# Altium schematic sheet BLE_Sensors_LEDs.SchDoc(BLE_Sensors_LEDs)
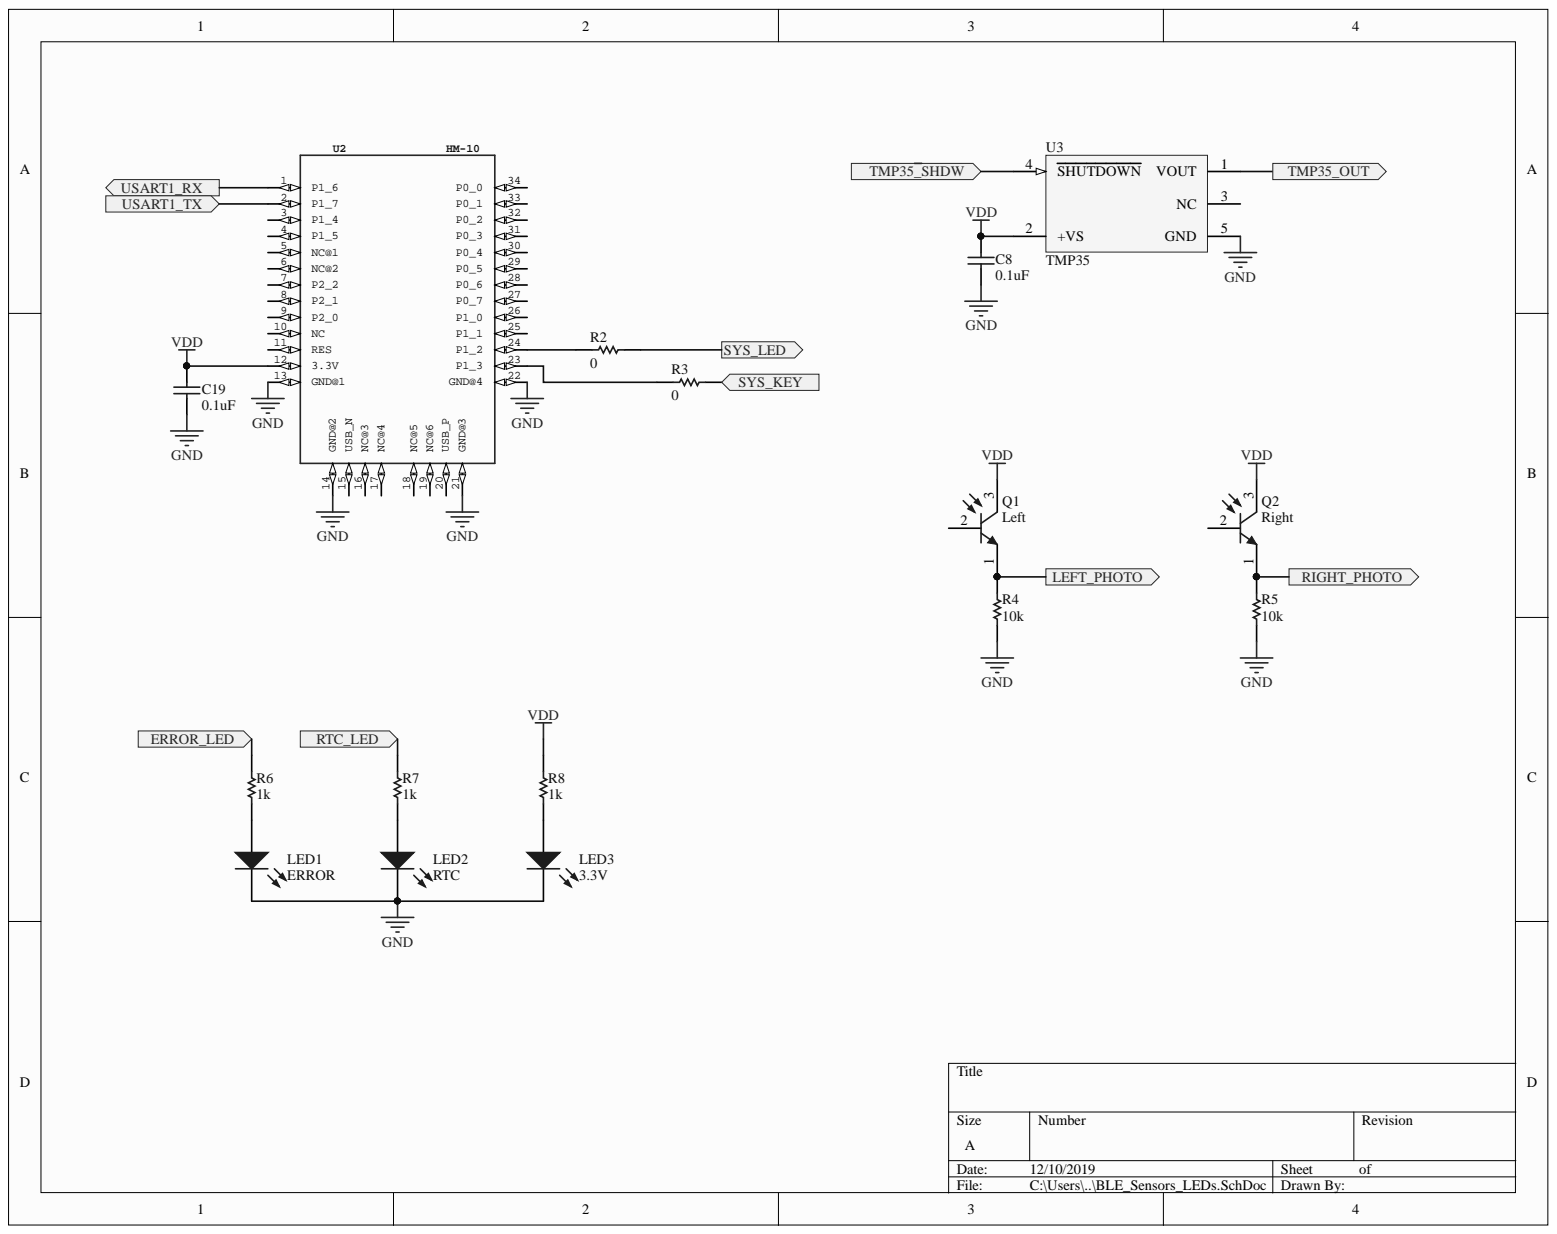
[diagram p0: BLE_Sensors_LEDs.SchDoc]

## Parts
C8  0.1uF  [CAPC1608X90X40ML10T20]
C19  0.1uF  [CAPC1608X90X40ML10T20]
LED1  ERROR  [0805_A]
LED2  RTC  [0805_A]
LED3  3.3V  [0805_A]
Q1  Left  [VSIL-TEMT6000X01_V]
Q2  Right  [VSIL-TEMT6000X01_V]
R2  0  [RESC2013X50X30LL20T20]
R3  0  [RESC2013X50X30LL20T20]
R4  10k  [RESC1608X55X30LL15T15]
R5  10k  [RESC1608X55X30LL15T15]
R6  1k  [RESC1608X55X30LL20T20]
R7  1k  [RESC1608X55X30LL20T20]
R8  1k  [RESC1608X55X30LL20T20]
U2  HM-10  [HM-10]
U3  TMP35  [ADI-RJ-5_M]

## Ports
ERROR_LED, LEFT_PHOTO, RIGHT_PHOTO, RTC_LED, SYS_KEY, SYS_LED, TMP35_OUT, TMP35~{_}SHDW, USART1_RX, USART1_TX

## Nets
GND: C8.1 C19.1 LED1.1 LED2.1 LED3.1 R4.1 R5.1 U2.13(GND@1) U2.14(GND@2) U2.21(GND@3) U2.22(GND@4) U3.5(GND)
VDD: C8.2 C19.2 Q1.3 Q2.3 R8.2 U2.12(3.3V) U3.2(+VS)
ERROR_LED: R6.2 ; port ERROR_LED
LEFT_PHOTO: Q1.1 R4.2 ; port LEFT_PHOTO
RIGHT_PHOTO: Q2.1 R5.2 ; port RIGHT_PHOTO
RTC_LED: R7.2 ; port RTC_LED
SYS_KEY: R3.2 ; port SYS_KEY
SYS_LED: R2.2 ; port SYS_LED
TMP35~{_}SHDW: U3.4(SHUTDOWN) ; port TMP35~{_}SHDW
TMP35_OUT: U3.1(VOUT) ; port TMP35_OUT
USART1_RX: U2.1(P1_6) ; port USART1_RX
USART1_TX: U2.2(P1_7) ; port USART1_TX
NetLED1_2: LED1.2 R6.1
NetLED2_2: LED2.2 R7.1
NetLED3_2: LED3.2 R8.1
NetR2_1: R2.1 U2.24(P1_2)
NetR3_1: R3.1 U2.23(P1_3)

Not connected: Q1 2; Q2 2; U2 3(P1_4) 4(P1_5) 5(NC@1) 6(NC@2) 7(P2_2) 8(P2_1) 9(P2_0) 10(NC) 11(RES) 15(USB_N) 16(NC@3) 17(NC@4) 18(NC@5) 19(NC@6) 20(USB_P) 25(P1_1) 26(P1_0) 27(P0_7) 28(P0_6) 29(P0_5) 30(P0_4) 31(P0_3) 32(P0_2) 33(P0_1) 34(P0_0); U3 3(NC)

## Library data of the parts
C8 C19 = CMP-2000-05002-1: Ceramic Multilayer Capacitor, 100 nF, +/- 10%, 50 V, -55 to 155 degC, 0603 (1608 Metric), RoHS, Tape and Reel; Manufacturer: Yageo; Voltage - Rated: 16V; Temperature Coefficient: X7R; Product Training Modules: MultilayerCeramicCapacitors; Other Names: 311-1088-6; Online Catalog: CCSeries; Featured Product: CommodityMultilayerCeramicCapacitors–CCSeries; Capacitance: 0.1µF; Tolerance: ±10%; Thickness (Max): 0.035"(0.90mm); Standard Package: 1; Size / Dimension: 0.063"Lx0.031"W(1.60mmx0.80mm); Series: CC; Ratings: -; Packaging: Digi-Reel; Package Reference: 603; Package Description: 2-Pin Surface Mount Device, Body 1.6 x 0.8 mm; Package / Case: 0603(1608Metric); Operating Temperature: -55degC~125degC; Mounting Type: SurfaceMount,MLCC; Manufacturer URL: http://www.yageo.com/; Library Name: Altium Content Vault; Lead Style: -; Lead Spacing: -; Height - Seated (Max): -; Features: -; Family: CeramicCapacitors; Datasheet Version: Rev. 2, 05/2009; Datasheet URL: https://datasheet.ciiva.com/11786/0900766b80d84f1d-11786077.pdf; Component Kind: Standard; Category: Capacitors; Applications: GeneralPurpose
LED1-LED3 = CMP-1426-00010-1: SMD mono-color Chip LED, WL-SMCW, Super Red; PartNumber: 150080SS75000; Manufacturer: Wuerth Elektronik; PackageReference: 0805_A; Library Name: Altium Content Vault; ComponentLink2URL: http://katalog.we-online.com/led/datasheet/150080SS75000.pdf; ComponentLink2Description: Datasheet; ComponentLink1URL: http://www.we-online.com; ComponentLink1Description: Manufacturer URL; Component Kind: Standard; Color: Super Red
Q1 Q2 = CMP-1666-00035-1: Ambient Light Sensor  , 100 mW, -40 to 100 degC, 3-Pin SMD, RoHS, Tape and Reel; Viewing Angle: 130 degrees; Power Rating: 75 mW; Nominal Vf: 2 V; Luminous Intensity: 54 mcd; Lens Style: Diffused; Illumination Colour: Red; Forward Current: 20 mA; Case\Package: 0603; Width: 0.8 mm; RoHSCompliant: true; Radiation Hardening: No; Pins: 2; Packaging: Tape and Reel; PackageReference: 1206; Package Quantity: 3000; PackageDescription: 3-Pin Surface Mount Device, Body 4 x 2 mm; Mount: Surface Mount; Min Operating Temperature: -55 degC; Max Operating Temperature: 85 degC; Length: 1.6 mm; Height: 0.8 mm; Forward Voltage: 2 V; DatasheetVersion: Rev. 1.9, 08/2011; ComponentLink2URL: http://www.vishay.com/docs/81579/temt6000.pdf; ComponentLink2Description: Datasheet; ComponentLink1URL: http://www.vishay.com/; ComponentLink1Description: Manufacturer URL; Component Kind: Standard; Colour: Red; Case Code (Metric): 1608; Case Code (Imperial): 0603; Library Name: VFD_Clock_lib.IntLib
R2 R3 = CMP-2001-04896-1: Part Number: CRCW08050000Z0EA; Manufacturer: Vishay Dale; Library Name: Altium Content Vault; Component Kind: Standard
R4 R5 = CMP-2000-00181-1: Part Number: ERJ-3EKF1002V; Manufacturer: Panasonic; Library Name: Altium Content Vault; Component Kind: Standard
R6-R8 = CMP-2000-00873-1: Part Number: ERA3AEB102V; Manufacturer: Panasonic; Library Name: Altium Content Vault; Component Kind: Standard
U2 = HM-10: GateName_1: G$1; SymbolName_1: HM-10; Package: HM-10; LibraryName: HM-10_BLE; Library Name: HM-10_BLE.IntLib; DeviceSetName: HM-10; Component Kind: Standard
U3 = CMP-0887-00046-1: Voltage Output Temperature Sensor, 2.7 to 5.5 V Supply, Industrial, 5-pin SOT23 (RJ-5), Reel; PartNumber: TMP35GRTZ-REEL7; Manufacturer: Analog Devices; Supply Voltage Range: 2.7 to 5.5 V; Packing: Reel; PackageVersion: 121608-A , 12/2008; PackageReference: RJ-5; PackageDescription: 5-Pin Small Outline Transistor Package, Body 2.9 x 1.6 mm, Pitch 0.95 mm; Mounting Technology: Surface Mount; DatasheetVersion: Rev. F; ComponentLink4URL: http://www.analog.com/en/mems-sensors/digital-temperature-sensors/tmp35/products/product.html; ComponentLink4Description: Product URL; ComponentLink3URL: http://www.analog.com/static/imported-files/packages/PKG_PDF/SOT-23(RJ)/RJ_5.pdf; ComponentLink3Description: Package Specification; ComponentLink2URL: http://www.analog.com/static/imported-files/data_sheets/TMP35_36_37.pdf; ComponentLink2Description: Datasheet; ComponentLink1URL: http://www.analog.com/en/index.html; ComponentLink1Description: Manufacturer URL; Component Kind: Standard; Code_JEDEC: MO-178-AA; Library Name: VFD_Clock_lib.IntLib
